# Altium schematic sheet Sheet1.SchDoc(Sheet1)
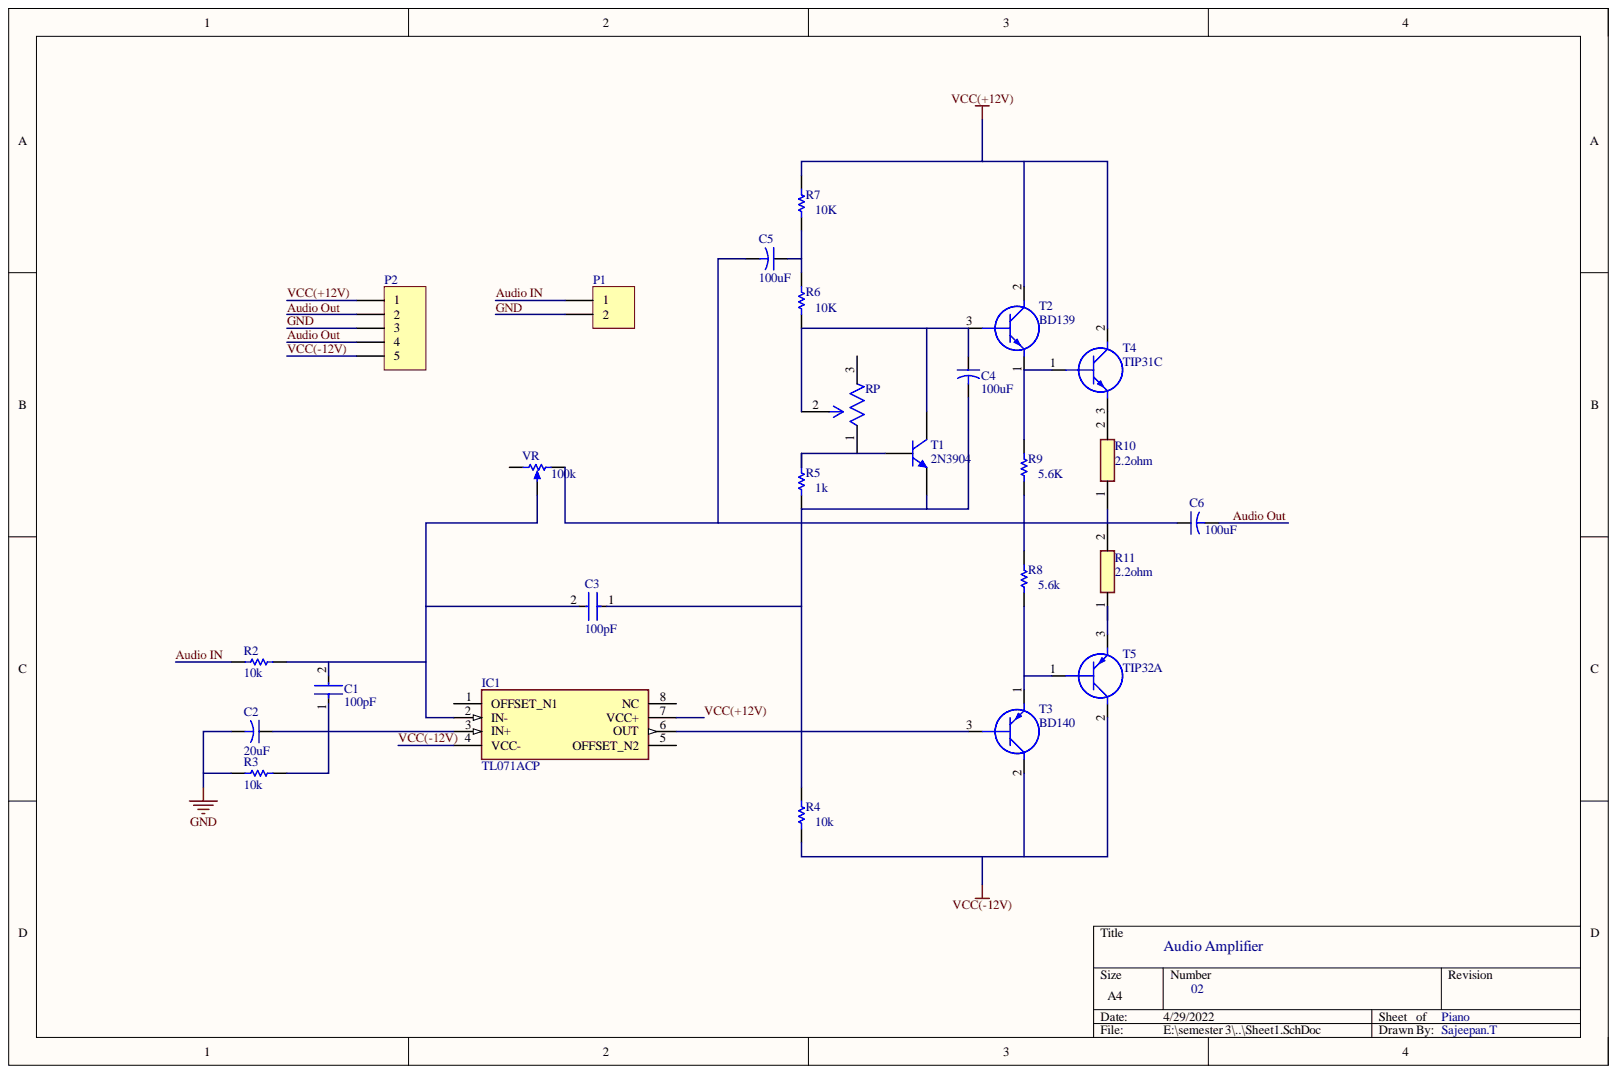
[diagram p0: Sheet1.SchDoc]

## Parts
C1  100pF  [FP-RHS0DG-MFG]
C2  value 20uF  [CAPR5-4X5]  (hidden comment: Cap2)
C3  100pF  [FP-RHS0DG-MFG]
C4  value 100uF  [CAPR5-4X5]  (hidden comment: Cap2)
C5  value 100uF  [CAPR5-4X5]  (hidden comment: Cap2)
C6  value 100uF  [CAPR5-4X5]  (hidden comment: Cap2)
IC1  TL071ACP  [DIP794W53P254L959H508Q8N]
P1  Header 2  [HDR1X2]  (value not drawn)
P2  Header 5  [HDR1X5]  (value not drawn)
R2  value 10k  [AXIAL-0.3]  (hidden comment: Res1)
R3  value 10k  [AXIAL-0.3]  (hidden comment: Res1)
R4  value 10k  [AXIAL-0.3]  (hidden comment: Res1)
R5  value 1k  [AXIAL-0.3]  (hidden comment: Res1)
R6  value 10K  [AXIAL-0.3]  (hidden comment: Res1)
R7  value 10K  [AXIAL-0.3]  (hidden comment: Res1)
R8  value 5.6k  [AXIAL-0.3]  (hidden comment: Res1)
R9  value 5.6K  [AXIAL-0.3]  (hidden comment: Res1)
R10  2.2ohm  [RESAD1130W50L370D190]
R11  2.2ohm  [RESAD1130W50L370D190]
RP  3386F-1-303LF  [FP-3386F-MFG]  (value not drawn)
T1  2N3904  [TO-92A]
T2  BD139  [TO229P300X760X1364-3P]
T3  BD140  [TO229P345X800X1460-3P]
T4  TIP31C  [TO254P470X1016X2019-3P]
T5  TIP32A  [TO254P470X1016X2019-3P]
VR  100k  [BOUR-3852A-282-103AL-3_V]

## Nets
Audio IN: P1.1 R2.2
Audio Out: C6.2 P2.2 P2.4
GND: C2.2 P1.2 P2.3 R3.2
VCC(+12V): IC1.7(VCC+) P2.1 R7.2 T2.2 T4.2
VCC(-12V): IC1.4(VCC-) P2.5 R4.1 T3.2 T5.2
NetC1_1: C1.1 C2.1 IC1.3(IN+) R3.1
NetC1_2: C1.2 C3.2 IC1.2(IN-) R2.1 VR.2
NetC3_1: C3.1 C4.2 IC1.6(OUT) R4.2 R5.1 T1.1 T3.3
NetC4_1: C4.1 R6.1 RP.2 T1.3 T2.3
NetC5_1: C5.1 R6.2 R7.1
NetC5_2: C5.2 C6.1 R8.2 R9.1 R10.1 R11.2 VR.3
NetR5_2: R5.2 RP.1 T1.2
NetR8_1: R8.1 T3.1 T5.1
NetR9_2: R9.2 T2.1 T4.1
NetR10_2: R10.2 T4.3
NetR11_1: R11.1 T5.3

Not connected: IC1 1(OFFSET_N1) 5(OFFSET_N2) 8(NC); RP 3; VR 1

## Library data of the parts
C1 C3 = CMP-06035-000016-1: CAP CER 100PF 100V CCG THRUHOLE; Manufacturer: Murata; Manufacturer Part Number: RHS7G2A101J0DGH01A; Automotive Grade: Grade 0; Material: Ceramic; Temperature Coefficient: 72ppm/°C; Tolerance: 5%; Automotive: Yes; Capacitance: 100pF; Category: Cap; Component Kind: Standard; ComponentLink2Description: Datasheet; ComponentLink2URL: https://search.murata.co.jp/Ceramy/image/img/A01X/G101/ENG/RHS_CCG_100V_E.pdf; Device Class L1: Passive Components; Device Class L2: Capacitors; Device Class L3: Ceramic Capacitors; Digikey Description: CAP CER 100PF 100V CCG THRUHOLE; Height: 5mm; Lead Free: Yes; Library Name: Altium Content Vault; Octopart: https://octopart.com/rhs7g2a101j0dgh01a-murata-91590056; Package: RHS0DG; RoHS: TRUE; Temperature: -55°C to +200°C; Temperature Characteristic: CCG; Temperature Range High: +200°C; Temperature Range Low: -55°C; Value: 100pF; Voltage Rating: 100V
C2 C4-C6 = Cap2: Capacitor; Component Kind: Standard; Library Name: Miscellaneous Devices.IntLib; PackageDescription: Capacitor; 2 Leads; PackageReference: CAPR5-4X5; Published: 23-Sep-2002; Signal Integrity: Cap; Simulation: CAP; Publisher: Altium Limited
IC1 = TL071ACP: Integrated Circuit; Manufacturer_Name: Texas Instruments; Arrow Part Number: TL071ACP; Arrow Price/Stock: https://www.arrow.com/en/products/tl071acp/texas-instruments?region=nac; Component Kind: Standard; Datasheet Link: http://www.ti.com/lit/ds/symlink/tl071a.pdf; Height: 5.08mm; Manufacturer_Part_Number: TL071ACP; Mouser Part Number: 595-TL071ACP; Mouser Price/Stock: https://www.mouser.co.uk/ProductDetail/Texas-Instruments/TL071ACP?qs=FM6NhYOeeBXD6FjYObfIhg%3D%3D
P1 = Header 2: Header, 2-Pin; Component Kind: Standard; LatestRevisionDate: 17-Jul-2002; LatestRevisionNote: Re-released for DXP Platform.; Library Name: Miscellaneous Connectors.IntLib; Signal Integrity: Connector; Publisher: Altium Limited
P2 = Header 5: Header, 5-Pin; Component Kind: Standard; LatestRevisionDate: 17-Jul-2002; LatestRevisionNote: Re-released for DXP Platform.; Library Name: Miscellaneous Connectors.IntLib; Signal Integrity: Connector; Publisher: Altium Limited
R2-R9 = Res1: Resistor; Component Kind: Standard; LatestRevisionDate: 17-Jul-2002; LatestRevisionNote: Re-released for DXP Platform.; Library Name: Miscellaneous Devices.IntLib; PackageDescription: Axial Device, Thru-Hole; 2 Leads; 0.3 in Pin Spacing; PackageReference: AXIAL-0.3; Published: 8-Jun-2000; Signal Integrity: Res; Simulation: RESISTOR; Publisher: Altium Limited
R10 R11 = MFF04FF6800A5: Resistor; Manufacturer_Name: ROYALOHM; Component Kind: Standard; Datasheet Link: https://www.tme.eu/Document/353a4c49cd04d4760ab7ace851501ebe/metal_film.pdf; Manufacturer_Part_Number: MFF04FF6800A5
RP = CMP-07234-000018-1: TRIMMER 30K OHM 0.5W PC PIN TOP; Manufacturer: Bourns; Resistance: 30kΩ; Standoff Height: 0.38mm; Temperature Coefficient: 100ppm/°C; Tolerance: 10%; Value: 30kΩ; Voltage Rating: 300V; Automotive: No; Category: Res; Component Kind: Standard; ComponentLink2Description: Datasheet; ComponentLink2URL: https://www.bourns.com/docs/Product-Datasheets/3386.pdf; Composition: Cermet; Device Class L1: Passive Components; Device Class L2: Resistors; Device Class L3: Variable Resistors and Potentiometers; Digikey Description: TRIMMER 30K OHM 0.5W PC PIN TOP; Height: 5.08mm; Lead Free: Yes; Library Name: Altium Content Vault; Manufacturer Part Number: 3386F-1-303LF; Mouser Description: Trimmer Resistors - Through Hole 3/8 30Kohms 10% 0.5Watts Square; Octopart: https://octopart.com/3386f-1-303lf-bourns-1032328; Package: 3386F; Power Rating: 0.5W; RoHS: TRUE; Series: 3386; Temperature: -55°C to +125°C; Temperature Range High: +125°C; Temperature Range Low: -55°C
T1 = 2N3904: NPN General Purpose Amplifier; Component Kind: Standard; ComponentLink1Description: Manufacturer Link; ComponentLink1URL: http://www.fairchildsemi.com/; ComponentLink2Description: Datasheet; ComponentLink2URL: http://www.fairchildsemi.com/ds/2N%2F2N3904.pdf; DatasheetVersion: 1997; LatestRevisionDate: 15-Jan-2003; LatestRevisionNote: PCB Footprint 'BCY-W3/D4.7' replaced; Library Name: Miscellaneous Devices.IntLib; PackageDescription: TO, Flat Index; 3 In-Line, Axial Leads; Body Dia. 4.5mm; Leads 0.51 x 0.48 mm (max); PackageReference: TO-92A; PackageVersion: Sep-1998; Published: 8-Jun-2000; Signal Integrity: 2N3904; Simulation: 2N3904; Publisher: Altium Limited
T2 = BD139: Transistor BJT NPN; Manufacturer_Name: ON Semiconductor; Component Kind: Standard; Datasheet Link: http://www.onsemi.com/pub/Collateral/BD135-D.PDF; Height: 3mm; Manufacturer_Part_Number: BD139; Mouser Part Number: 863-BD139; Mouser Price/Stock: https://www.mouser.co.uk/ProductDetail/onsemi/BD139?qs=vNc2DXHODiI0%252BZZC8g2wVg%3D%3D
T3 = BD14010STU: Transistor BJT PNP; Manufacturer_Name: ON Semiconductor; Arrow Part Number: BD14010STU; Arrow Price/Stock: https://www.arrow.com/en/products/bd14010stu/on-semiconductor?region=nac; Component Kind: Standard; Datasheet Link: http://www.mouser.com/ds/2/149/BD140-888626.pdf; Height: 3.45mm; Manufacturer_Part_Number: BD14010STU; Mouser Part Number: 512-BD14010STU; Mouser Price/Stock: https://www.mouser.co.uk/ProductDetail/ON-Semiconductor-Fairchild/BD14010STU?qs=ljbEvF4DwOOnoRfj89Fh0g%3D%3D
T4 = TIP31C: Transistor BJT NPN; Manufacturer_Name: Bourns; Component Kind: Standard; Datasheet Link: https://www.mouser.com/datasheet/2/149/fairchild%20semiconductor_tip31a-549394.pdf; Height: 4.7mm; Manufacturer_Part_Number: TIP31C
T5 = TIP32A: Transistor BJT PNP; Manufacturer_Name: ON Semiconductor; Arrow Part Number: TIP32A; Arrow Price/Stock: https://www.arrow.com/en/products/tip32a/on-semiconductor; Component Kind: Standard; Datasheet Link: https://www.jameco.com/Jameco/Products/ProdDS/33064.pdf; Height: 4.7mm; Manufacturer_Part_Number: TIP32A; Mouser Part Number: 512-TIP32A; Mouser Price/Stock: https://www.mouser.co.uk/ProductDetail/ON-Semiconductor-Fairchild/TIP32A?qs=P36CEcFBmYytOK49o0vnfw%3D%3D
VR = CMP-2000-05079-1: Diameter Panel Control, 10 KOhm, +/- 10%, 2 W, -1 to 125 degC, 3-Pin THD, RoHS, Bulk; Manufacturer: Bourns; Actuator Diameter: 0.250"(6.35mm); Actuator Length: 0.875"(22.23mm); Actuator Type: Slotted; Associated Product: 36-8560-ND  -KNOBINSTRUMW/DIALRND.9"DIA  36-8555-ND  -KNOBINSTRUMSKIRTEDRND.7"DIA  36-8556-ND  -KNOBINSTRUMSKIRTEDRND.9"DIA  36-8565-ND  -KNOBINSTRUMDBLBARRND.7"DIA  36-8566-ND  -KNOBINSTRUMDBLBARRND.9"DIA  36-8568-ND  -KNOBINSTRUMSGLBARRND.7"DIA  36-8569-ND  -KNOBINSTRUMSGLBARRND.9"DIA  36-8559-ND  -KNOBINSTRUMW/DIALRND.7"DIA  226-1006-ND  -KNOBBLKGLOSS.75"DIA.250"SHAFT  226-1007-ND  -KNOBBLKGLOSS.925"DIA.250"SHAFT  226-1011-ND  -KNOBBLKGLOS; Built in Switch: None; Catalog Drawings: 3852SeriesSide1    3852SeriesSide2; Number of Gangs: 1; Online Catalog: 3852and3856Series; Other Names: 3852A282103AL; PCN Design/Specification: PBTResinJun/2011; PCN Other: MultipleChanges01/Dec/2012; Power (Watts): 2W; Resistance (Ohms): 10k; Resistive Material: Cermet; Rotation: 280deg; Temperature Coefficient: ±150ppm/degC; Bushing Thread: 3/8-32; Category: Potentiometers,VariableResistors; Component Kind: Standard; Datasheet URL: https://datasheet.ciiva.com/11831/0900766b80f93b4b-11831016.pdf; Datasheet Version: Sep-14; Family: RotaryPotentiometers,Rheostats; Library Name: Altium Content Vault; Manufacturer URL: http://www.bourns.com/; Mounting Type: PanelMount; Number of Turns: 1; Packaging: Bulk; RoHS Information: 3852A-LMaterialDeclaration; Series: 3852; Standard Package: 45; Taper: Linear; Termination Style: SolderLug; Tolerance: ±10%
